# Altium schematic sheet INA260.SchDoc(INA260)
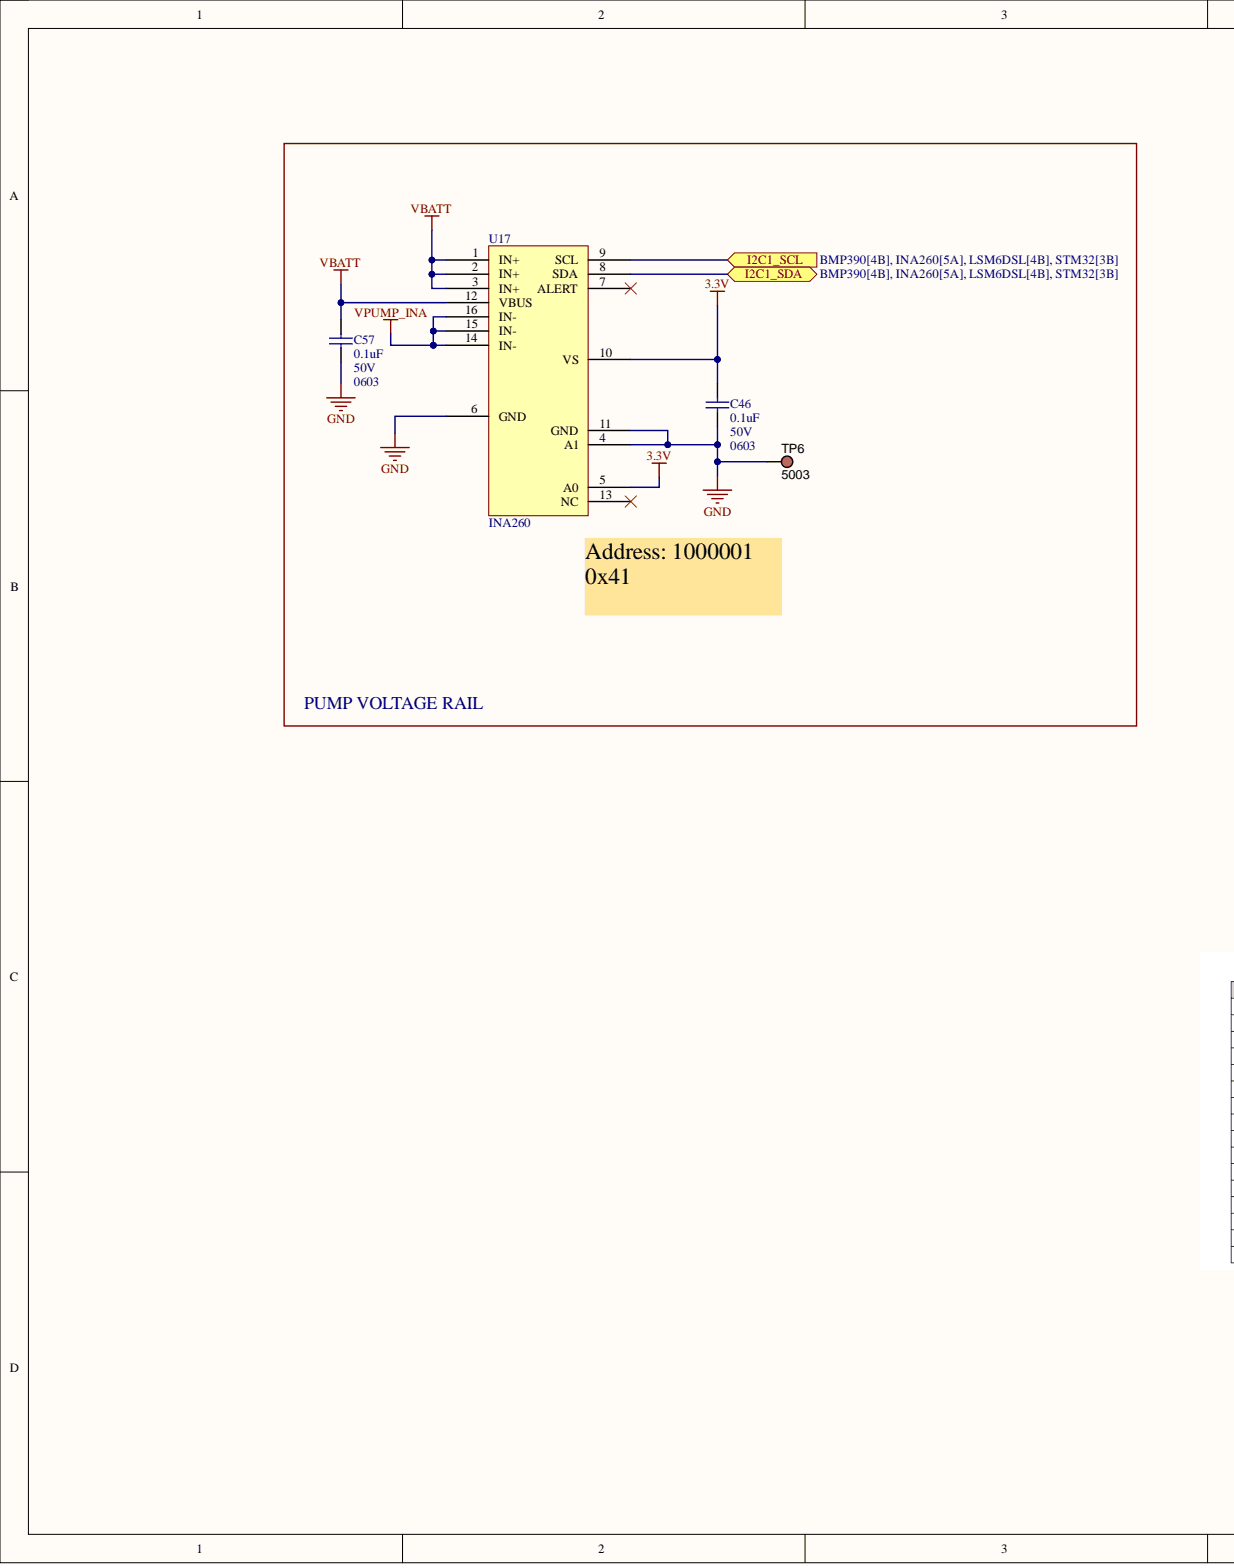
[diagram p0: INA260.SchDoc, left]
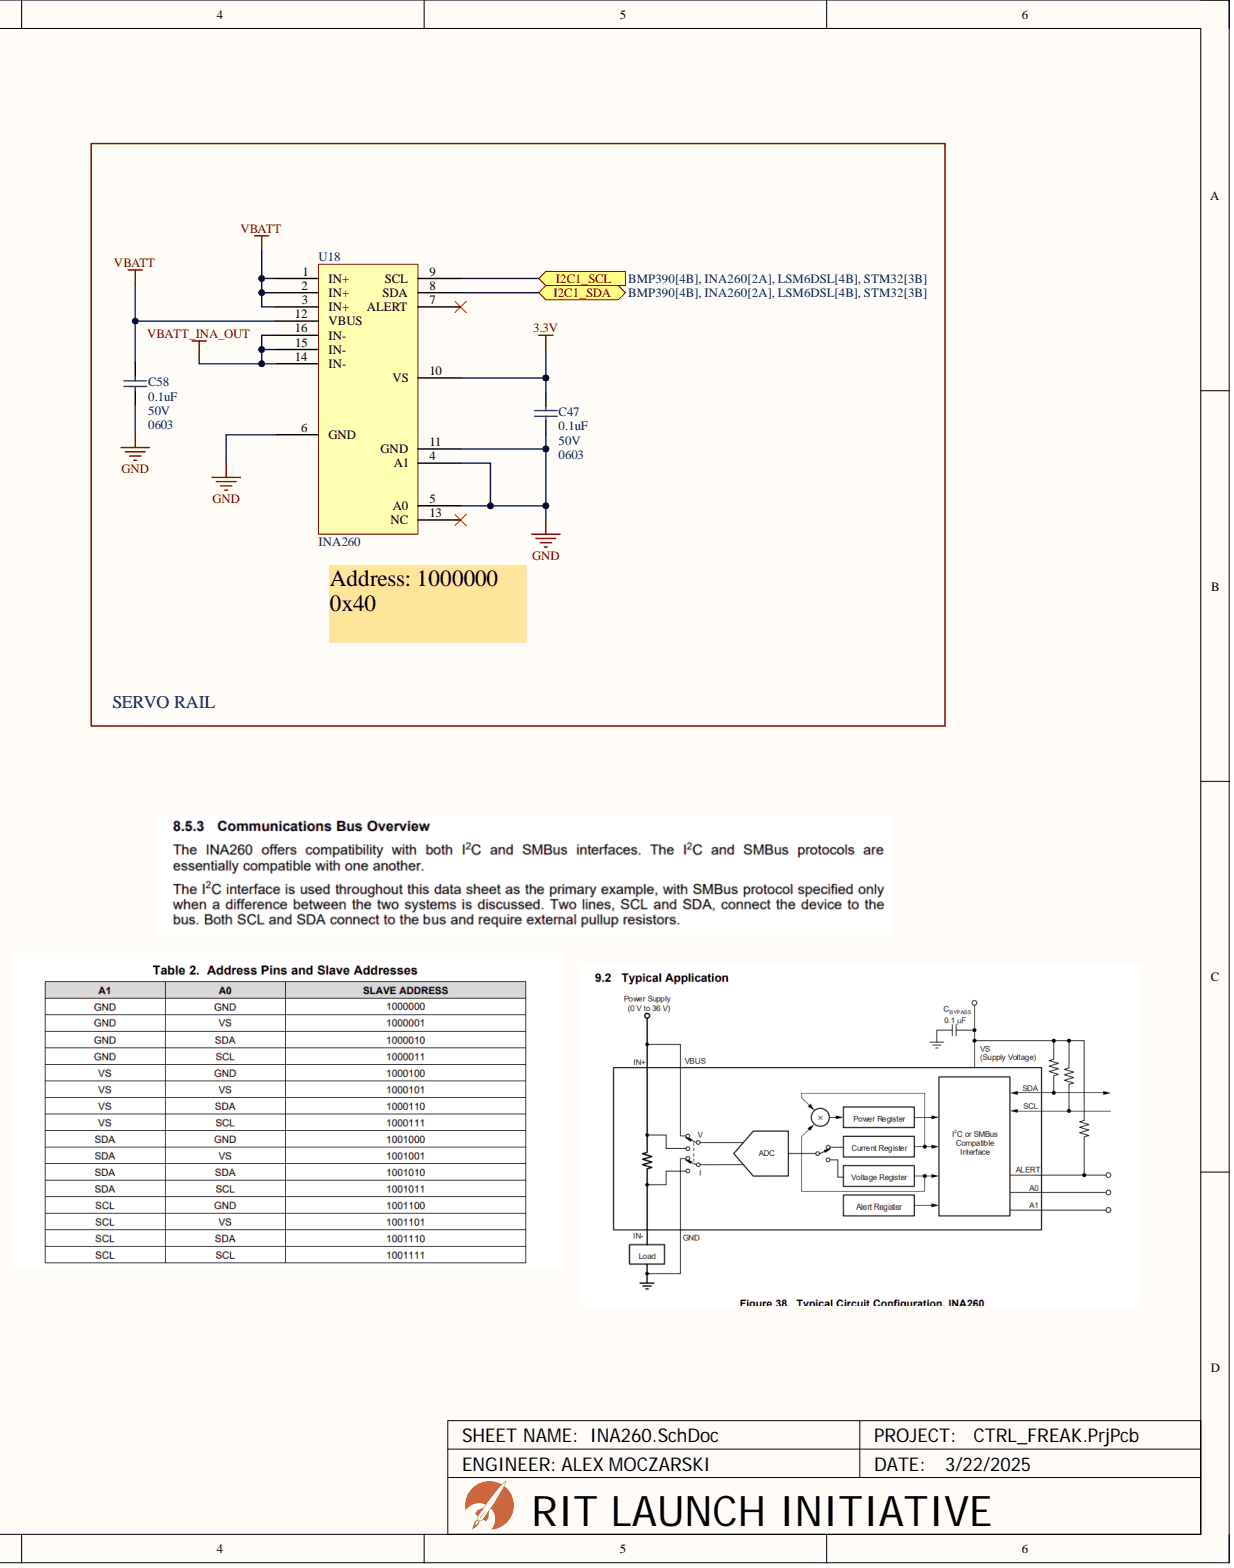
[diagram p1: INA260.SchDoc, right]

## Parts
C46  Capacitance: 0.1uF  Case Code (Imperial): 0603  Voltage: 50V  (hidden comment: CC0603KRX7R9BB104)
C47  Capacitance: 0.1uF  Case Code (Imperial): 0603  Voltage: 50V  (hidden comment: CC0603KRX7R9BB104)
C57  Capacitance: 0.1uF  Case Code (Imperial): 0603  Voltage: 50V  (hidden comment: CC0603KRX7R9BB104)
C58  Capacitance: 0.1uF  Case Code (Imperial): 0603  Voltage: 50V  (hidden comment: CC0603KRX7R9BB104)
TP6  5003
U17  INA260  Nominal Supply Voltage: 3.3V
U18  INA260

## Ports
I2C1_SCL, I2C1_SDA

## Nets
3.3V: C46.2 C47.2 U17.5(A0) U17.10(VS) U18.10(VS)
GND: C46.1 C47.1 C57.1 C58.1 TP6.1 U17.4(A1) U17.6(GND) U17.11(GND) U18.4(A1) U18.5(A0) U18.6(GND) U18.11(GND)
VBATT: C57.2 C58.2 U17.1(IN+) U17.2(IN+) U17.3(IN+) U17.12(VBUS) U18.1(IN+) U18.2(IN+) U18.3(IN+) U18.12(VBUS)
VBATT_INA_OUT: U18.14(IN-) U18.15(IN-) U18.16(IN-)
VPUMP_INA: U17.14(IN-) U17.15(IN-) U17.16(IN-)
I2C1_SCL: U17.9(SCL) U18.9(SCL) ; port I2C1_SCL
I2C1_SDA: U17.8(SDA) U18.8(SDA) ; port I2C1_SDA

Not connected: U17 7(ALERT) 13(NC); U18 7(ALERT) 13(NC)

## Library data of the parts
C46 C47 C57 C58 = CMP-001-0242-3: CAP CER 0.1UF 50V X7R 0603; Dielectric Material: Ceramic; Tolerance: 10%; Case Code (Metric): 1608; Case/Package: 0603; Component Kind: Standard; Depth: 0.8mm; Dielectric: X7R; Halogen Free: Halogen Free; Height: 0.8mm; JLCPCB Part #: C14663; Lead Free: Lead Free; Length: 0.063inch; Max Operating Temperature: 125°C; Min Operating Temperature: -55°C; Mount: Surface Mount; Number of Pins: 2; Package Quantity: 1; Packaging: Tape and Reel; Radiation Hardening: No; REACH SVHC: No SVHC; RoHS Compliant: Yes; Series: CC; Termination: SMD/SMT; Thickness: 0.035inch; Voltage Rating: 50V; Width: 0.031inch; Library Name: RIT Launch Initiative
TP6 = CMP-002-00082-1: Test Point, Orange, Height 4.6 mm, Tail Length 3 mm, 1-Pin THD, RoHS; Manufacturer: Keystone; Case/Package: 5003; Colour: Orange; Component Kind: Standard; Connector Type: TestPoint; Contact Material: Bronze; Contact Plating: Tin; Datasheet URL: http://keyelco.com/userAssets/file/M65p56.pdf; Datasheet Version: Jun-15; Diameter: 2.54mm; Manufacturer URL: http://www.keyelco.com/; Mounting Technology: TH; Package Description: 1-Pin Through Hole Device, Dia 2.54 mm; Package Quantity: 10; Package Reference: 5003; Packaging: Bulk; Pins: 1; RoHS Compliant: True; Library Name: RIT Launch Initiative
U17 = CMP-001-0376-2: Operational Amplifier, 1 Func, 7500uV Offset-Max, PDSO16; Adjustable Threshold: No; Channels: 1; Max Supply Voltage: 5.5V; Min Supply Voltage: 2.7V; Package Body Material: Plastic; Throw Configuration: POWER SUPPLY SUPPORT CIRCUIT; Case/Package: TSSOP; Component Kind: Standard; Height - Seated (Max): 1.2mm; JESD-30 Code: R-PDSO-G16; JESD-609 Code: e4; JLCPCB Part #: C2155885; Length: 5mm; Max Operating Temperature: 125°C; Min Operating Temperature: -40°C; Moisture Sensitivity Level: 2; Number of Channels: 1; Package Shape: Rectangular; Package Style: SMALL OUTLINE, THIN PROFILE, SHRINK PITCHMeter; Pins: 16; Reach SVHC Compliant: Yes; RoHS Compliant: Yes; Surface Mount: Yes; Temperature Grade: AUTOMOTIVE; Terminal Finish: Nickel; Terminal Form: Gull Wing; Terminal Pitch: 0.65mm; Terminal Position: DUAL; Width: 4.4mm; Library Name: RIT Launch Initiative
U18 = CMP-001-0376-2: Operational Amplifier, 1 Func, 7500uV Offset-Max, PDSO16; Adjustable Threshold: No; Channels: 1; Max Supply Voltage: 5.5V; Min Supply Voltage: 2.7V; Nominal Supply Voltage: 3.3V; Package Body Material: Plastic; Throw Configuration: POWER SUPPLY SUPPORT CIRCUIT; Case/Package: TSSOP; Component Kind: Standard; Height - Seated (Max): 1.2mm; JESD-30 Code: R-PDSO-G16; JESD-609 Code: e4; JLCPCB Part #: C2155885; Length: 5mm; Max Operating Temperature: 125°C; Min Operating Temperature: -40°C; Moisture Sensitivity Level: 2; Number of Channels: 1; Package Shape: Rectangular; Package Style: SMALL OUTLINE, THIN PROFILE, SHRINK PITCHMeter; Pins: 16; Reach SVHC Compliant: Yes; RoHS Compliant: Yes; Surface Mount: Yes; Temperature Grade: AUTOMOTIVE; Terminal Finish: Nickel; Terminal Form: Gull Wing; Terminal Pitch: 0.65mm; Terminal Position: DUAL; Width: 4.4mm; Library Name: RIT Launch Initiative
